# Altium schematic sheet MCU_PROGRAMMING.SchDoc(MCU_PROGRAMMING)
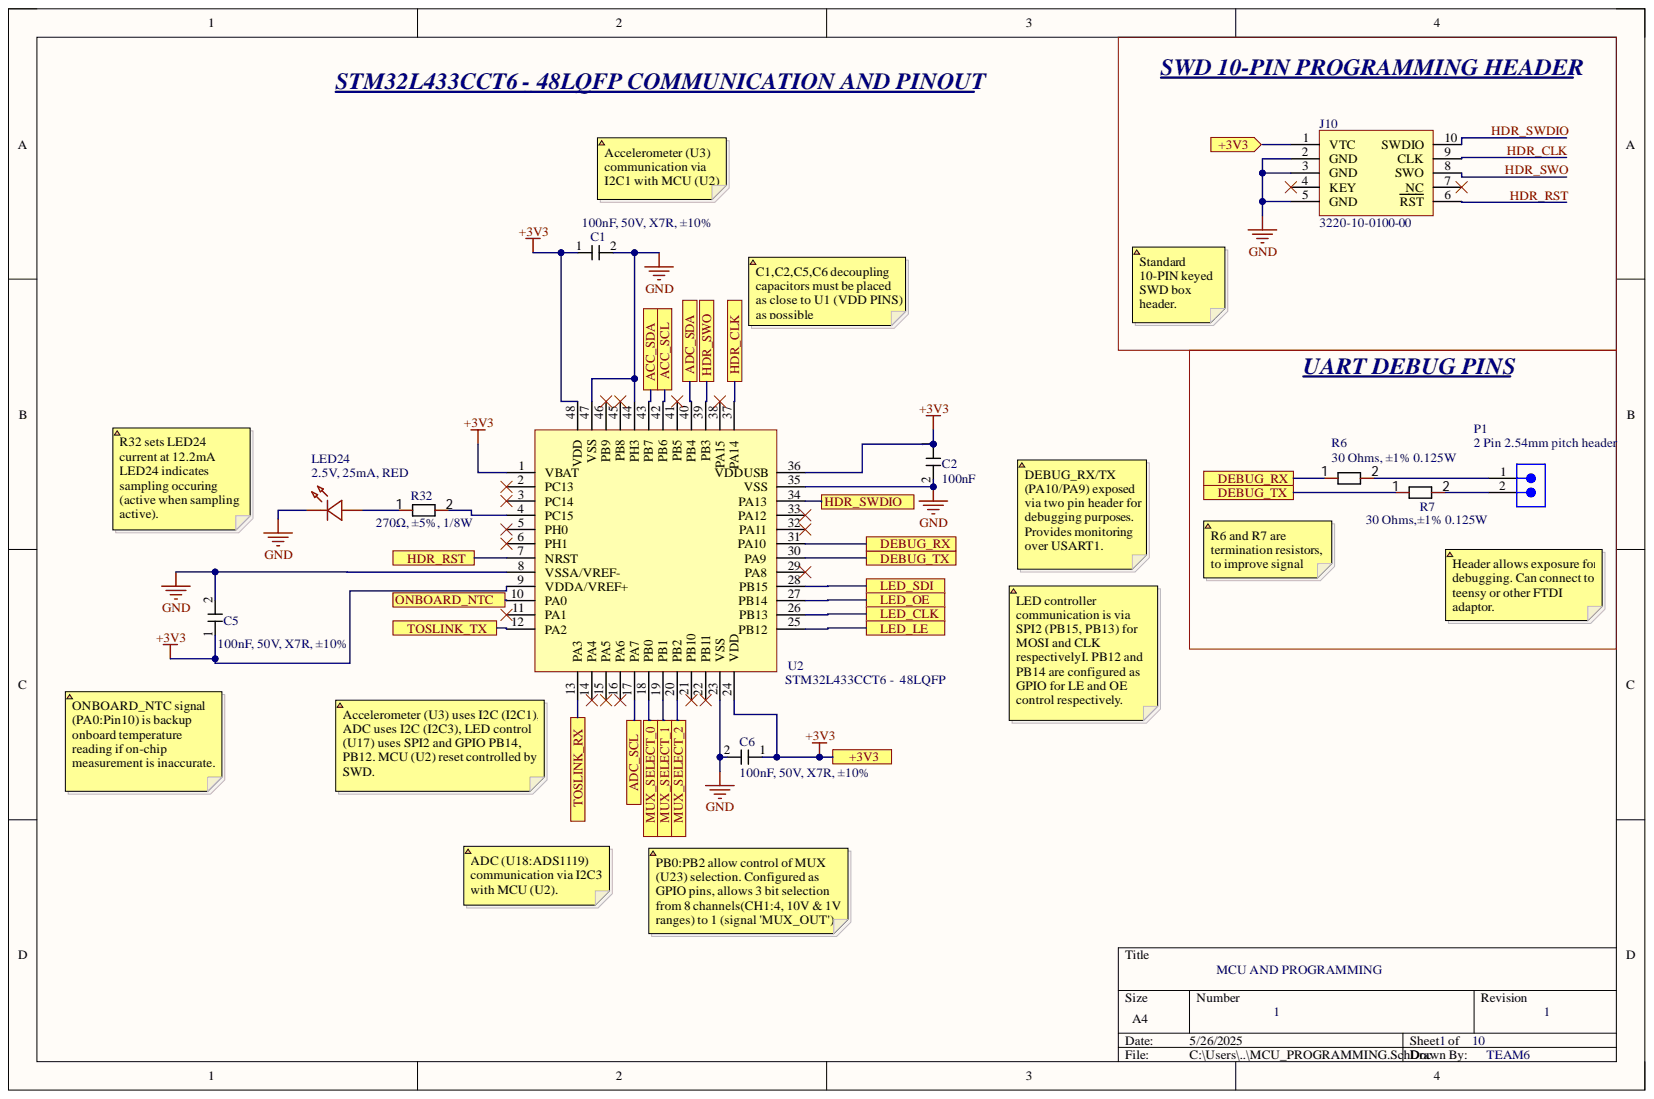
[diagram p0: MCU_PROGRAMMING.SchDoc]

## Parts
C1  100nF, 50V, X7R, ±10%  [RC0805N_YAG]
C2  100nF  [RC0805N_YAG]
C5  100nF, 50V, X7R, ±10%  [RC0805N_YAG]
C6  100nF, 50V, X7R, ±10%  [RC0805N_YAG]
J10  3220-10-0100-00  [J-link]
LED24  2.5V, 25mA, RED  [LED0805]
P1  2 Pin 2.54mm pitch header  Lead Pitch: 2.54mm  [61300211121]
R6  30 Ohms, ±1% 0.125W  [0805_R]
R7  30 Ohms,±1% 0.125W  [0805_R]
R32  270Ω, ±5%, 1/8W  [0805_R]
U2  STM32L433CCT6 -  48LQFP  [LQFP48-7x7mm]

## Ports
+3V3, ACC_SCL, ACC_SDA, ADC_SCL, ADC_SDA, DEBUG_RX, DEBUG_TX, HDR_CLK, HDR_RST, HDR_SWDIO, HDR_SWO, LED_CLK, LED_LE, LED_OE, LED_SDI, MUX_SELECT_0, MUX_SELECT_1, MUX_SELECT_2, ONBOARD_NTC, TOSLINK_RX, TOSLINK_TX

## Nets
GND: C1.2 C2.2 C5.2 C6.2 J10.2(GND) J10.3(GND) J10.5(GND) LED24.1 U2.8(VSSA/VREF-) U2.23(VSS) U2.35(VSS) U2.44(PH3) U2.47(VSS)
+3V3: C1.1 C2.1 C5.1 C6.1 U2.1(VBAT) U2.9(VDDA/VREF+) U2.24(VDD) U2.36(VDDUSB) U2.48(VDD) ; port +3V3
ACC_SCL: U2.42(PB6) ; port ACC_SCL
ACC_SDA: U2.43(PB7) ; port ACC_SDA
ADC_SCL: U2.17(PA7) ; port ADC_SCL
ADC_SDA: U2.40(PB4) ; port ADC_SDA
DEBUG_RX: R6.1 U2.31(PA10) ; port DEBUG_RX
DEBUG_TX: R7.1 U2.30(PA9) ; port DEBUG_TX
HDR_CLK: J10.9(CLK)
HDR_RST: J10.6(RST)
HDR_SWDIO: J10.10(SWDIO)
HDR_SWO: J10.8(SWO)
LED_CLK: U2.26(PB13) ; port LED_CLK
LED_LE: U2.25(PB12) ; port LED_LE
LED_OE: U2.27(PB14) ; port LED_OE
LED_SDI: U2.28(PB15) ; port LED_SDI
MUX_SELECT_0: U2.18(PB0) ; port MUX_SELECT_0
MUX_SELECT_1: U2.19(PB1) ; port MUX_SELECT_1
MUX_SELECT_2: U2.20(PB2) ; port MUX_SELECT_2
ONBOARD_NTC: U2.10(PA0) ; port ONBOARD_NTC
TOSLINK_RX: U2.13(PA3) ; port TOSLINK_RX
TOSLINK_TX: U2.12(PA2) ; port TOSLINK_TX
NetLED24_2: LED24.2 R32.1
NetP1_1: P1.1 R6.2
NetP1_2: P1.2 R7.2
NetR32_2: R32.2 U2.4(PC15)
NetU2_7: U2.7(NRST) ; port HDR_RST
NetU2_34: U2.34(PA13) ; port HDR_SWDIO
NetU2_37: U2.37(PA14) ; port HDR_CLK
NetU2_39: U2.39(PB3) ; port HDR_SWO

Not connected: J10 1(VTC) 4(KEY) 7(NC); U2 2(PC13) 3(PC14) 5(PH0) 6(PH1) 11(PA1) 14(PA4) 15(PA5) 16(PA6) 21(PB10) 22(PB11) 29(PA8) 32(PA11) 33(PA12) 38(PA15) 41(PB5) 45(PB8) 46(PB9)

## Library data of the parts
C1 C2 C5 C6 = CAP 103 10nF 1206: Component Kind: Standard; Library Name: Schlib1.SchLib
J10 = J-link: 3220-10-0100-00, 10-PIN SWD HEADER; Component Kind: Standard; Library Name: Schlib1.SchLib
LED24 = LED0805: Component Kind: Standard; Description: 2.5V, 25mA, RED; Library Name: 3800.SchLib
P1 = CMP-1712-00002-6: NexarPartId: 20081794; Connector Type: Male Pin; Contact Resistance: 20mΩ; Current Rating: 3A; Gender: Male; Number of Contacts: 2; Operating Supply Voltage: 250V; Color: Black; Component Kind: Standard; Contact Gender: Male; Contact Material: Copper; Contact Mating Length: 5.9944mm; Contact Plating: Gold; Description: CMP-1712-00002-6; Height: 8.94mm; Housing Material: PBT; Lead Free: Lead Free; Library Name: Altium Content Vault; Max Operating Temperature: 125°C; Min Operating Temperature: -40°C; Mount: Through Hole; Number of Pins: 2; Number of Positions: 2; Number of Rows: 1; Orientation: Vertical; Packaging: Bulk; Pitch: 2.54mm; REACH SVHC: No SVHC; RoHS: Compliant; Termination: Through Hole; Voltage Rating: 250V
R6 = 0805-R: RC0805FR-0730RL 30 Ohms ±1% 0.125W, 1/8W Chip Resistor 0805 (2012 Metric) Moisture Resistant Thick Film; Component Kind: Standard; Library Name: Product-PCB.SchLib
R7 = 0805-R: RC0805FR-0730RL RC0805FR-0730RL 30 Ohms ±1% 0.125W, 1/8W Chip Resistor 0805 (2012 Metric) Moisture Resistant Thick Film; Component Kind: Standard; Library Name: Product-PCB.SchLib
R32 = 0805-R: 270 Ohms ±5% 0.125W, 1/8W Chip Resistor 0805 (2012 Metric) Moisture Resistant Thick Film; Component Kind: Standard; Library Name: Product-PCB.SchLib
U2 = STM32: Component Kind: Standard; Library Name: Schlib1.SchLib
